# Altium schematic sheet Power.SchDoc
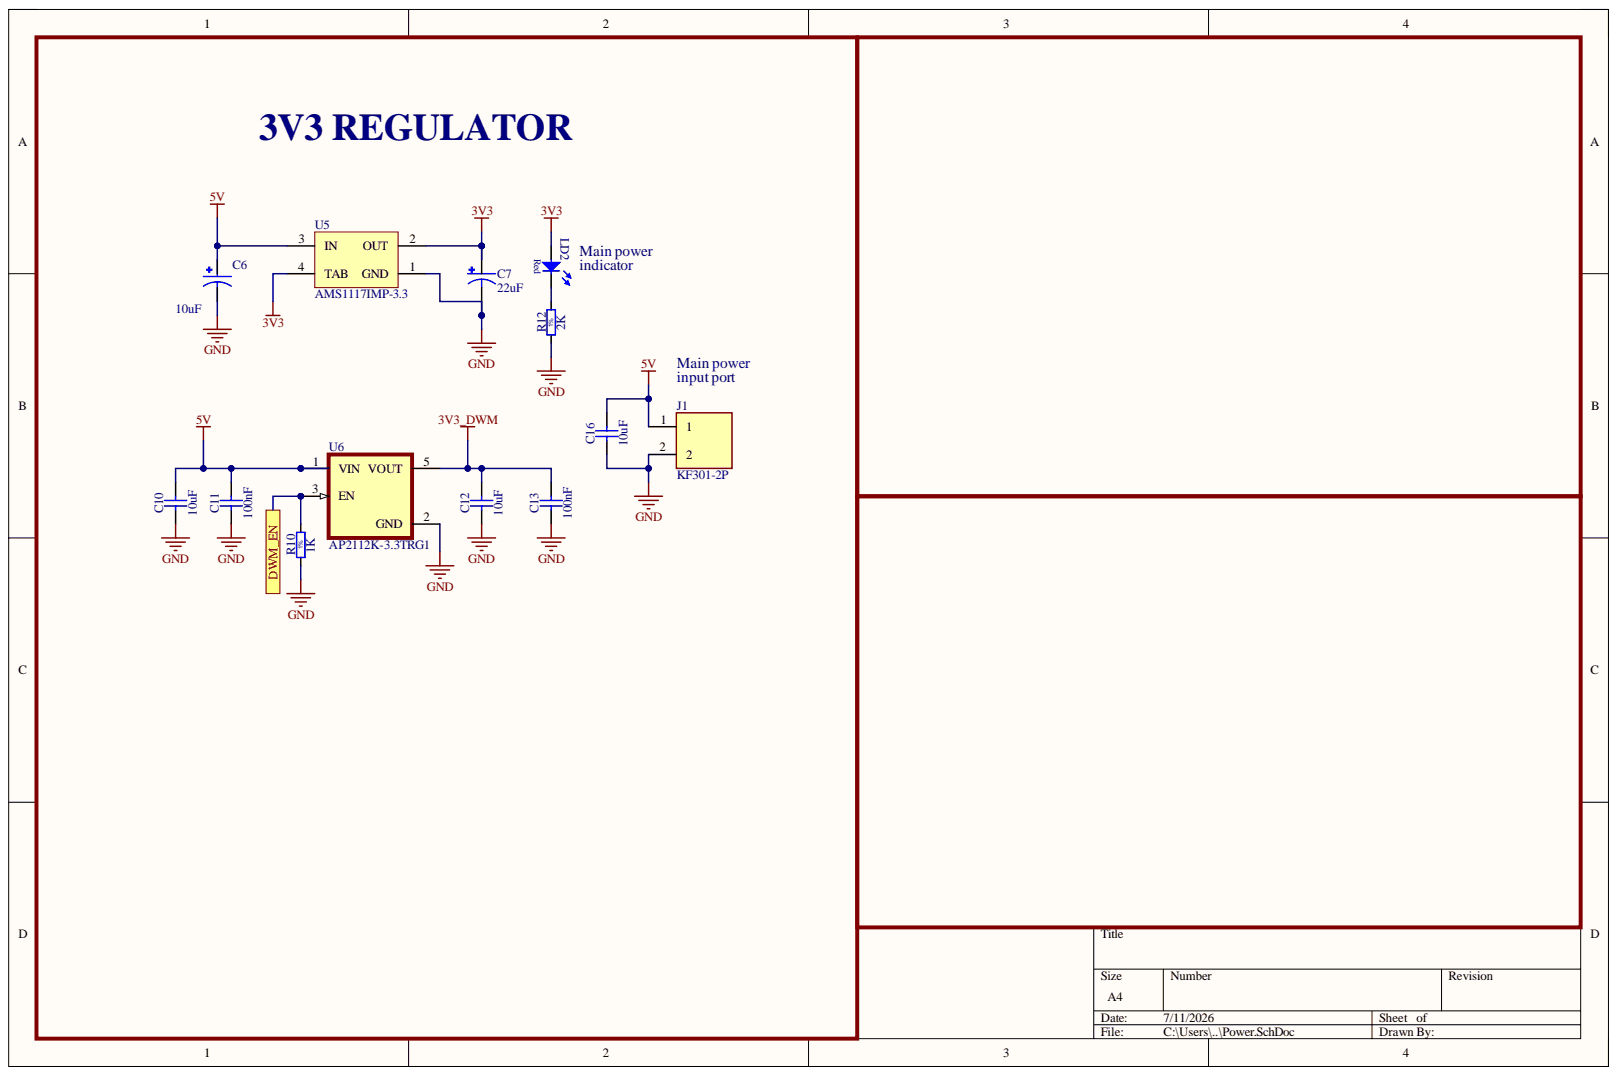
[diagram p0: Power.SchDoc]

## Parts
C6  10uF  [CAPMP3216X180N]
C7  22uF  [CAPMP3528X210N]
C10  10uF  [0603C]
C11  100nF  [0603C]
C12  10uF  [0603C]
C13  100nF  [0603C]
C16  10uF  [0603C]
J1  KF301-2P  [HANDSON_KF301-2P]
LD2  (no value)  [0603 LED]
R10  1K  [0603R]
R12  2K  [0603R]
U5  AMS1117IMP-3.3  [VREG_LM1117IMP-3.3/NOPB]
U6  AP2112K-3.3TRG1  [SOT95P285X140-5N]

## Ports
DWM_EN

## Nets
3V3: C7.1 LD2.2 U5.2(OUT) U5.4(TAB)
3V3_DWM: C12.2 C13.2 U6.5(VOUT)
5V: C6.1 C10.2 C11.2 C16.2 J1.1 U5.3(IN) U6.1(VIN)
GND: C6.2 C7.2 C10.1 C11.1 C12.1 C13.1 C16.1 J1.2 R10.1 R12.1 U5.1(GND) U6.2(GND)
DWM_EN: R10.2 U6.3(EN) ; port DWM_EN
NetLD2_1: LD2.1 R12.2

## Library data of the parts
C6 = T543A106K010ATW080: 10 ÂµF Molded Tantalum Polymer Capacitor 10 V 1206 (3216 Metric) 80mOhm @ 100kHz; MF: KEMET; Check_prices: https://www.snapeda.com/parts/T543A106K010ATW080/Kemet/view-part/?ref=eda; Component Kind: Standard; Library Name: T543A106K010ATW080.IntLib; MP: T543A106K010ATW080; Package: SMD-2 Kemet; SnapEDA_Link: https://www.snapeda.com/parts/T543A106K010ATW080/Kemet/view-part/?ref=snap
C7 = TPSB226K016R0600: Capacitor,Tantalum,Cap 22 uF,Tol 10%,Vol-Rtg 16 VDC,SMT,3528,ESR 600 mOhms,CT | AVX TPSB226K016R0600; Check_prices: https://www.snapeda.com/parts/TPSB226K016R0600/AVX/view-part/?ref=eda; Component Kind: Standard; Library Name: TPSB226K016R0600.IntLib; MF: AVX; MP: TPSB226K016R0600; Package: 1210 AVX; SnapEDA_Link: https://www.snapeda.com/parts/TPSB226K016R0600/AVX/view-part/?ref=snap
C10-C13 C16 = Capacitor - non polarized: Non-polarised capacitor; Component Kind: Standard; Library Name: Universal Acq.IntLib
J1 = KF301-2P: 2 Pin Terminal Block 5mm Pitch; MF: Handson Technology; Check_prices: https://www.snapeda.com/parts/KF301-2P/Handson+Technology/view-part/?ref=eda; Component Kind: Standard; Library Name: KF301-2P.IntLib; MP: KF301-2P; Package: None; SnapEDA_Link: https://www.snapeda.com/parts/KF301-2P/Handson+Technology/view-part/?ref=snap
LD2 = LED Red 1C 2A: Component Kind: Standard; Description: LED Red 1C 2A; Library Name: Universal Acq.IntLib
R10 R12 = Resistor - 1%_1: Resistor - 1%; Component Kind: Standard; Library Name: Universal Acq.IntLib
U5 = LM1117IMP-3.3: 800mA Low-Dropout Linear Regulator, 4-pin SOT-223; Manufacturer: National Semiconductor; CompType: LDO IC; High-Reliability: Y; PackageTopMarking: XYTT*N05B; PowerWise: N; Code_IPC: SOT223-4; Code_JEDEC: TO-261-AA; Component Kind: Standard; ComponentLink1Description: Manufacturer URL; ComponentLink1URL: http://www.national.com/; ComponentLink2Description: LM1117 Datasheet; ComponentLink2URL: http://www.national.com/ds/LM/LM1117.pdf; ComponentLink3Description: LM1117 Web Page; ComponentLink3URL: http://www.national.com/pf/LM/LM1117.html; ComponentLink4Description: MP04A Package Page; ComponentLink4URL: http://www.national.com/packaging/folders/mp04a.html; DatasheetVersion: Apr-2006; Library Name: National Semiconductor LDO.IntLib; PackageDescription: Molded SOT-223, 6.50 x 3.56 x 1.6mm Body, 4 Lead, 2.3mm Pitch; PackageReference: MP04A; PackageVersion: revB, Apr-2003; PartNumber: LM1117IMP-3.3; PinCount: 4; RoHS: N
U6 = AP2112K-3.3TRG1: Linear Voltage Regulator IC Positive Fixed 1 Output 600mA SOT-25; MF: Diodes Inc.; Availability: In Stock; Check_prices: https://www.snapeda.com/parts/AP2112K-3.3TRG1/Diodes+Inc./view-part/?ref=eda; Component Kind: Standard; Library Name: AP2112K-3.3TRG1.IntLib; MP: AP2112K-3.3TRG1; Package: SOT-753 Diodes Inc.; Price: None; Purchase-URL: https://pricing.snapeda.com/search/part/AP2112K-3.3TRG1/?ref=eda; SnapEDA_Link: https://www.snapeda.com/parts/AP2112K-3.3TRG1/Diodes+Inc./view-part/?ref=snap
